# Altium schematic sheet 06_SFP Connector.SchDoc
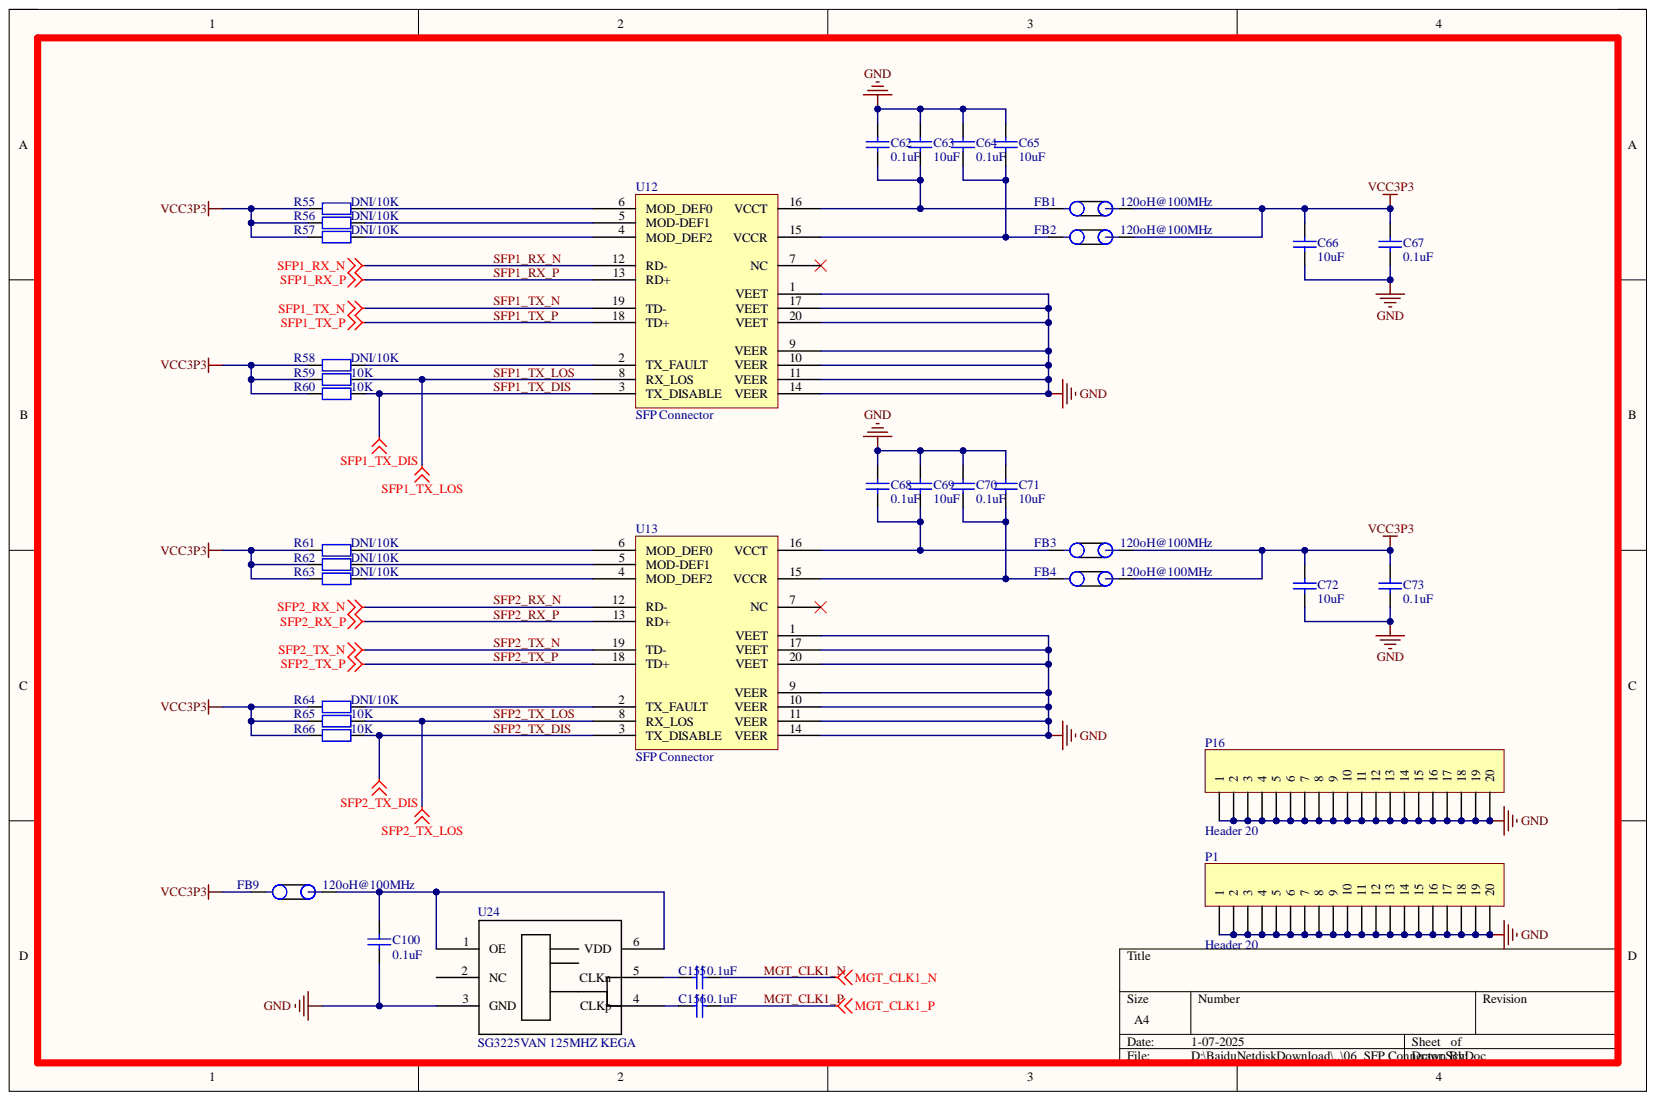
[diagram p0: 06_SFP Connector.SchDoc]

## Parts
C62  0.1uF  [C0603]
C63  10uF  [C0805]
C64  0.1uF  [C0603]
C65  10uF  [C0805]
C66  10uF  [C0805]
C67  0.1uF  [C0603]
C68  0.1uF  [C0603]
C69  10uF  [C0805]
C70  0.1uF  [C0603]
C71  10uF  [C0805]
C72  10uF  [C0805]
C73  0.1uF  [C0603]
C100  0.1uF  [C0603]
C155  0.1uF  [C0603]
C156  0.1uF  [C0603]
FB1  120oH@100MHz  [L0603]
FB2  120oH@100MHz  [L0603]
FB3  120oH@100MHz  [L0603]
FB4  120oH@100MHz  [L0603]
FB9  120oH@100MHz  [L0603]
P1  Header 20  [46012-000001]
P16  Header 20  [46012-000001]
R55  DNI/10K  [0603R]
R56  DNI/10K  [0603R]
R57  DNI/10K  [0603R]
R58  DNI/10K  [0603R]
R59  10K  [0603R]
R60  10K  [0603R]
R61  DNI/10K  [0603R]
R62  DNI/10K  [0603R]
R63  DNI/10K  [0603R]
R64  DNI/10K  [0603R]
R65  10K  [0603R]
R66  10K  [0603R]
U12  SFP Connector  [1367073-1]
U13  SFP Connector  [1367073-1]
U24  SG3225VAN 125MHZ KEGA  [TCXO3225_6P]

## Nets
GND: C62.2 C63.2 C64.2 C65.2 C66.2 C67.2 C68.2 C69.2 C70.2 C71.2 C72.2 C73.2 C100.2 P1.1 P1.2 P1.3 P1.4 P1.5 P1.6 P1.7 P1.8 P1.9 P1.10 P1.11 P1.12 P1.13 P1.14 P1.15 P1.16 P1.17 P1.18 P1.19 P1.20 P16.1 P16.2 P16.3 P16.4 P16.5 P16.6 P16.7 P16.8 P16.9 P16.10 P16.11 P16.12 P16.13 P16.14 P16.15 P16.16 P16.17 P16.18 P16.19 P16.20 U12.1(VEET) U12.9(VEER) U12.10(VEER) U12.11(VEER) U12.14(VEER) U12.17(VEET) U12.20(VEET) U13.1(VEET) U13.9(VEER) U13.10(VEER) U13.11(VEER) U13.14(VEER) U13.17(VEET) U13.20(VEET) U24.3(GND)
VCC3P3: C66.1 C67.1 C72.1 C73.1 FB1.2 FB2.2 FB3.2 FB4.2 FB9.1 R55.2 R56.2 R57.2 R58.2 R59.2 R60.2 R61.2 R62.2 R63.2 R64.2 R65.2 R66.2
MGT_CLK1_N: C155.1
MGT_CLK1_P: C156.1
SFP1_RX_N: U12.12(RD-)
SFP1_RX_P: U12.13(RD+)
SFP1_TX_DIS: R60.1 U12.3(TX_DISABLE)
SFP1_TX_LOS: R59.1 U12.8(RX_LOS)
SFP1_TX_N: U12.19(TD-)
SFP1_TX_P: U12.18(TD+)
SFP2_RX_N: U13.12(RD-)
SFP2_RX_P: U13.13(RD+)
SFP2_TX_DIS: R66.1 U13.3(TX_DISABLE)
SFP2_TX_LOS: R65.1 U13.8(RX_LOS)
SFP2_TX_N: U13.19(TD-)
SFP2_TX_P: U13.18(TD+)
NetC62_1: C62.1 C63.1 FB1.1 U12.16(VCCT)
NetC64_1: C64.1 C65.1 FB2.1 U12.15(VCCR)
NetC68_1: C68.1 C69.1 FB3.1 U13.16(VCCT)
NetC70_1: C70.1 C71.1 FB4.1 U13.15(VCCR)
NetC100_1: C100.1 FB9.2 U24.1(OE) U24.6(VDD)
NetC155_2: C155.2 U24.5(CLKn)
NetC156_2: C156.2 U24.4(CLKp)
NetR55_1: R55.1 U12.6(MOD_DEF0)
NetR56_1: R56.1 U12.5(MOD-DEF1)
NetR57_1: R57.1 U12.4(MOD_DEF2)
NetR58_1: R58.1 U12.2(TX_FAULT)
NetR61_1: R61.1 U13.6(MOD_DEF0)
NetR62_1: R62.1 U13.5(MOD-DEF1)
NetR63_1: R63.1 U13.4(MOD_DEF2)
NetR64_1: R64.1 U13.2(TX_FAULT)

Not connected: U12 7(NC); U13 7(NC); U24 2(NC)

## Library data of the parts
C62-C73 C100 C155 C156 = Cap: Capacitor; Component Kind: Standard; LatestRevisionDate: 17-Jul-2002; LatestRevisionNote: Re-released for DXP Platform.; Library Name: Miscellaneous Devices.IntLib; PackageDescription: Radial Cap, Thru-Hole; 2 Leads; 0.3 in Pin Spacing; PackageReference: RAD-0.3; Published: 8-Jun-2000; Signal Integrity: Cap; Simulation: CAP; Publisher: Altium Limited
  C62 C64 C67 C68 C70 C73 C100 C155 C156: Value: 0.1uF
  C63 C65 C66 C69 C71 C72: Value: 10uF
FB1-FB4 FB9 = CiZhu: Component Kind: Standard; Description: CiZhu; Library Name: zynq.SCHLIB; Value: 120oH@100MHz
P1 P16 = Header 20: Header, 20-Pin; Component Kind: Standard; LatestRevisionDate: 17-Jul-2002; LatestRevisionNote: Re-released for DXP Platform.; Library Name: Miscellaneous Connectors.IntLib; Signal Integrity: Connector; Publisher: Altium Limited
R55-R66 = Res2: Resistor; Component Kind: Standard; LatestRevisionDate: 17-Jul-2002; LatestRevisionNote: Re-released for DXP Platform.; PackageReference: AXIAL-0.4; Published: 8-Jun-2000; Library Name: 元件封装库.pcblib; Publisher: Altium Limited
  R55-R58 R61-R64: Value: DNI/10K
  R59 R60 R65 R66: Value: 10K
U12 U13 = SFP Connector: SPF Connector; Component Kind: Standard; Library Name: 7015.SchLib
U24 = DIFF: Component Kind: Standard; Library Name: 7015.SchLib
